# Altium schematic sheet MMC_2_4_PSU.SchDoc(MMC_2_4_PSU)
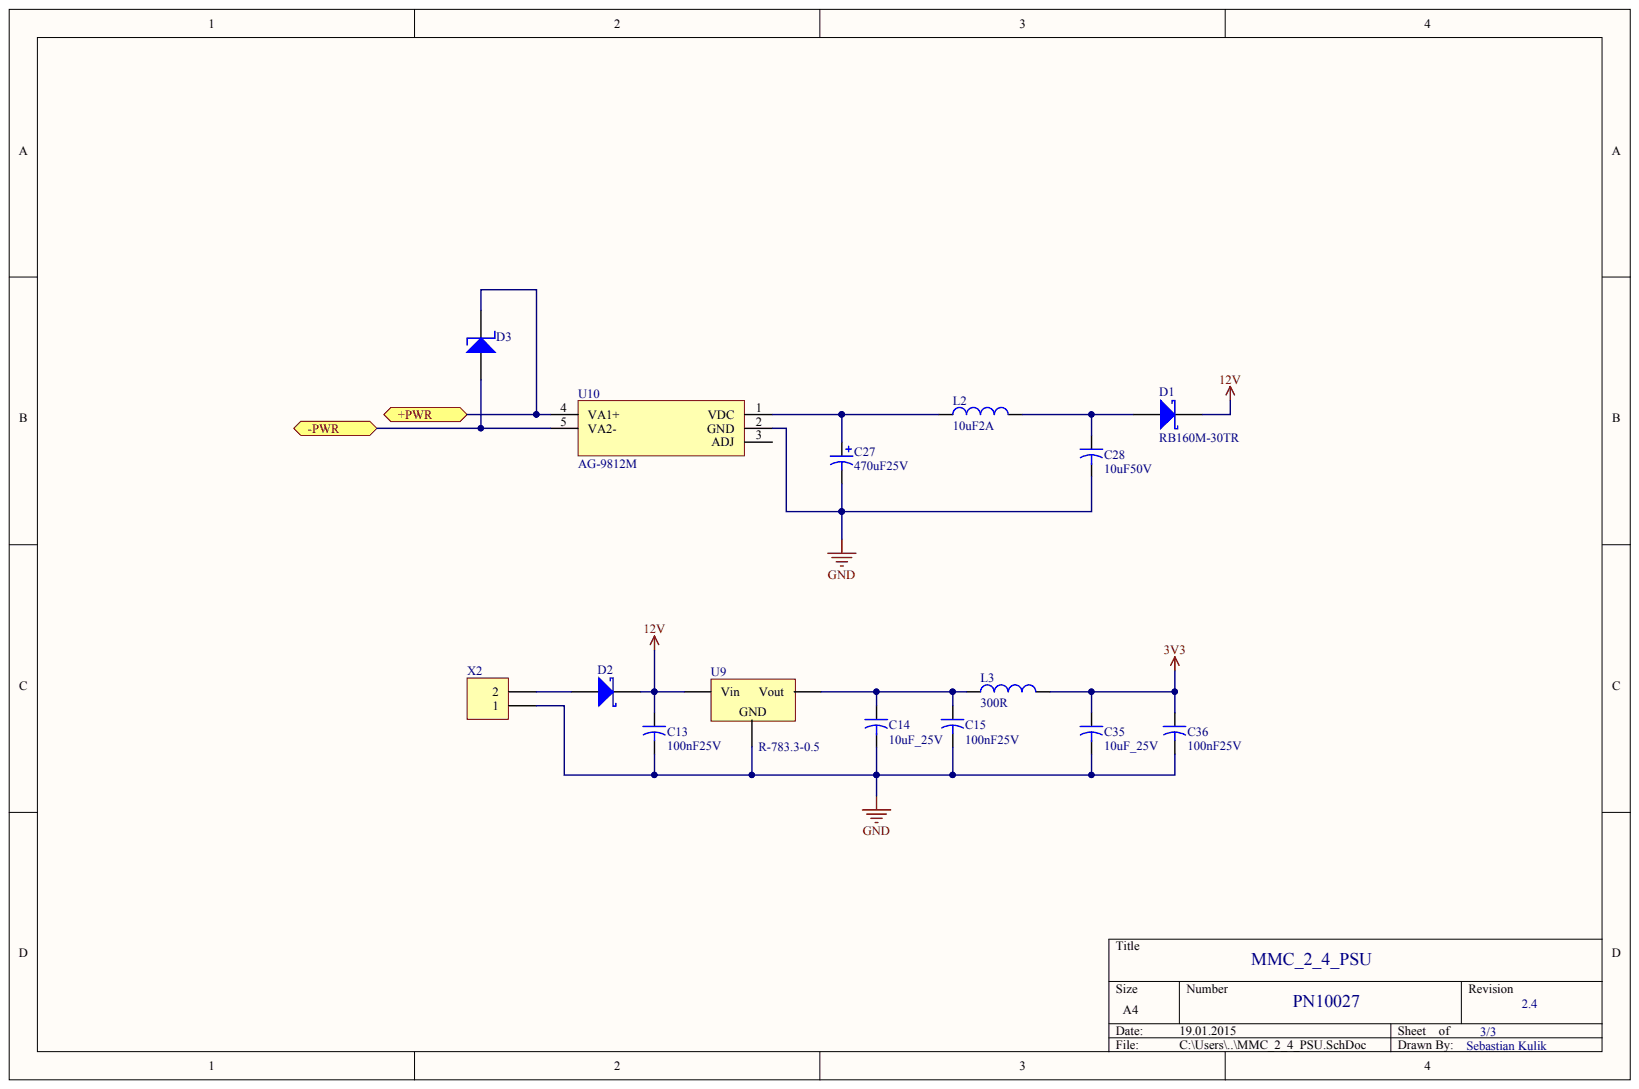
[diagram p0: MMC_2_4_PSU.SchDoc]

## Parts
C13  value 100nF25V  [C0402]  (hidden comment: 100nF25V_0402)
C14  value 10uF_25V  [C1210]  (hidden comment: 10uF25V_1210)
C15  value 100nF25V  [C0402]  (hidden comment: 100nF25V_0402)
C27  value 470uF25V  [Panasonic-FT-F_Case]  (hidden comment: 470uF25V_POL_FT)
C28  value 10uF50V  [C1210]  (hidden comment: 10uF50V_1210)
C35  value 10uF_25V  [C1210]  (hidden comment: 10uF25V_1210)
C36  value 100nF25V  [C0402]  (hidden comment: 100nF25V_0402)
D1  RB160M-30TR  [SOD123]
D2  RB160M-30TR  [SOD123]
D3  SMAJ58A-E3/61  [DO214A]  (value not drawn)
L2  10uF2A  [WE-PD2_1]
L3  value 300R  [L0603]  (hidden comment: 300R2A_0603)
U9  R-783.3-0.5  [R-783_3-0_5]
U10  AG-9812M  [Ag9812M]
X2  WR-TBL3127  [WR-TBL-3127]  (value not drawn)

## Ports
+PWR, -PWR

## Nets
3V3: C35.1 C36.1 L3.2
12V: C13.1 D1.1 D2.1 U9.1
GND: C13.2 C14.2 C15.2 C27.2 C28.2 C35.2 C36.2 U9.2 U10.2(GND) X2.1
NetC14_1: C14.1 C15.1 L3.1 U9.3
NetC27_1: C27.1 L2.1 U10.1(VDC)
NetC28_1: C28.1 D1.2 L2.2
NetD2_2: D2.2 X2.2
NetD3_1: D3.1 U10.4(VA1+)
NetD3_2: D3.2 U10.5(VA2-)

Not connected: U10 3(ADJ)

## Library data of the parts
C13 C15 C36 = 100nF25V_0402: AVX, X5R, 10%, 25V; Deliverer PartNr: 1833862; Manufacturer PartNr: 04023D104KAT2A; Deliverer: Farnell; TDE-PN: C0036; Component Kind: Standard; Library Name: Capacitors.IntLib; Manufacturer: AVX; PackageDescription: C0402; Part Description: AVX, X5R, 10%, 25V; Published: 09.12.2014; Signal Integrity: Cap; Simulation: CAP; Publisher: Sebastian Kulik
C14 C35 = 10uF25V_1210: TDK  C series, X5R, 10%, 25V; Deliverer PartNr: 2346957; Manufacturer PartNr: C3225X5R1E106K250AA; Deliverer: Farnell; TDE-PN: C0014; Component Kind: Standard; Library Name: Capacitors.IntLib; Manufacturer: TDK; PackageDescription: C1210; Part Description: TDK  C series, X5R, 10%, 25V; Published: 12.02.2014; Signal Integrity: Cap; Simulation: CAP; Publisher: Sebastian Kulik
C27 = 470uF25V_POL_FT: Panasonic, FT series; Deliverer PartNr: 1868411; Manufacturer PartNr: EEEFT1E471AP; Deliverer: Farnell; TDE-PN: C0048; Component Kind: Standard; Library Name: Capacitors.IntLib; Manufacturer: Panasonic; PackageDescription: Panasonic-FT-F; Part Description: Panasonic, FT series; Published: 23.09.2014; Signal Integrity: Cap; Simulation: CAP; Publisher: Sebastian Kulik
C28 = 10uF50V_1210: AVX,  X7R, 10%, 50V; Deliverer PartNr: 2094047RL; Manufacturer PartNr: 12105C106KAT2A; Deliverer: Farnell; TDE-PN: C0039; Component Kind: Standard; Library Name: Capacitors.IntLib; Manufacturer: AVX; PackageDescription: C1210; Part Description: AVX,  X7R, 10%, 50V; Published: 17.02.2014; Signal Integrity: Cap; Simulation: CAP; Publisher: Sebastian Kulik
D1 D2 = RB160M-30TR: Diode Schottky,2A,40V; Deliverer PartNr: 1525480; Manufacturer: Rohm; Deliverer: Farnell; TDE-PN: DIS0010; Component Kind: Standard; Library Name: Discrete.IntLib; Manufacturer PartNr: RB160M-30TR; PackageDescription: SOD123; Published: 17.02.2014; Simulation: 18TQ045; Publisher: Sebastian Kulik
D3 = SMAJ58A-E3/61: TVS, 400W, 58V; Deliverer PartNr: 1837053; Manufacturer: Vishay; Deliverer: Farnell; TDE-PN: DIS0009; Component Kind: Standard; Library Name: Discrete.IntLib; Manufacturer PartNr: SMAJ58A-E3/61; PackageDescription: DO214AC; Published: 17.02.2014; Simulation: 18TQ045; Publisher: Sebastian Kulik
L2 = 10uF2A_WE-PD2: WE-PD2, 10uF2A; Deliverer PartNr: 1636035; Manufacturer: WE; Manufacturer PartNr: 74477510; Deliverer: Farnell; TDE-PN: P0017; Component Kind: Standard; Library Name: Passives.IntLib; Published: 17.02.2014; Value: 10uF2A; Publisher: Sebastian Kulik
L3 = 300R2A_0603: WE, Ferrit, 300Rx2A; Deliverer PartNr: 1635705; Manufacturer PartNr: 742792641; Deliverer: Farnell; TDE-PN: P0021; Component Kind: Standard; Library Name: Passives.IntLib; Manufacturer: WE; PackageDescription: L0603; Published: 26.05.2014; Publisher: Sebastian Kulik
U9 = Recom-R-783_3-0_5: Voltage Regulator; Deliverer PartNr: 532-8044; Manufacturer: Recom; Deliverer: RS-Components; TDE-PN: IC0032; Component Kind: Standard; Library Name: ICs.IntLib; Manufacturer PartNr: R-783.3-0.5; PackageDescription: R-783_3-0_5/D-PACK; Published: 12.02.2014; Publisher: Sebastian Kulik
U10 = AG-9812M: PoE, 12V; Manufacturer: Silvertel; Deliverer: Codico; TDE-PN: IC0052; Component Kind: Standard; Deliverer PartNr: AG-9812M; Library Name: ICs.IntLib; Manufacturer PartNr: AG-9812M; PackageDescription: AG9812M; Published: 17.02.2014; Publisher: Sebastian Kulik
X2 = Header-2-WR-TBL3127: Header 2 pin, horizontal,WR-TBL3127; Deliverer PartNr: 1841288; Manufacturer: WE; Manufacturer PartNr: 691312710002; Deliverer: Farnell; TDE-PN: CON0014; Component Kind: Standard; LatestRevisionDate: 28.05.2014; LatestRevisionNote: Re-released for DXP Platform.; Library Name: Connectors.IntLib; PackageDescription: WR-TBL-3127; Signal Integrity: Connector; Publisher: Sebastian Kulik
